# Altium schematic sheet Pag5_Perifericos.SchDoc(Pag5_Perifericos)
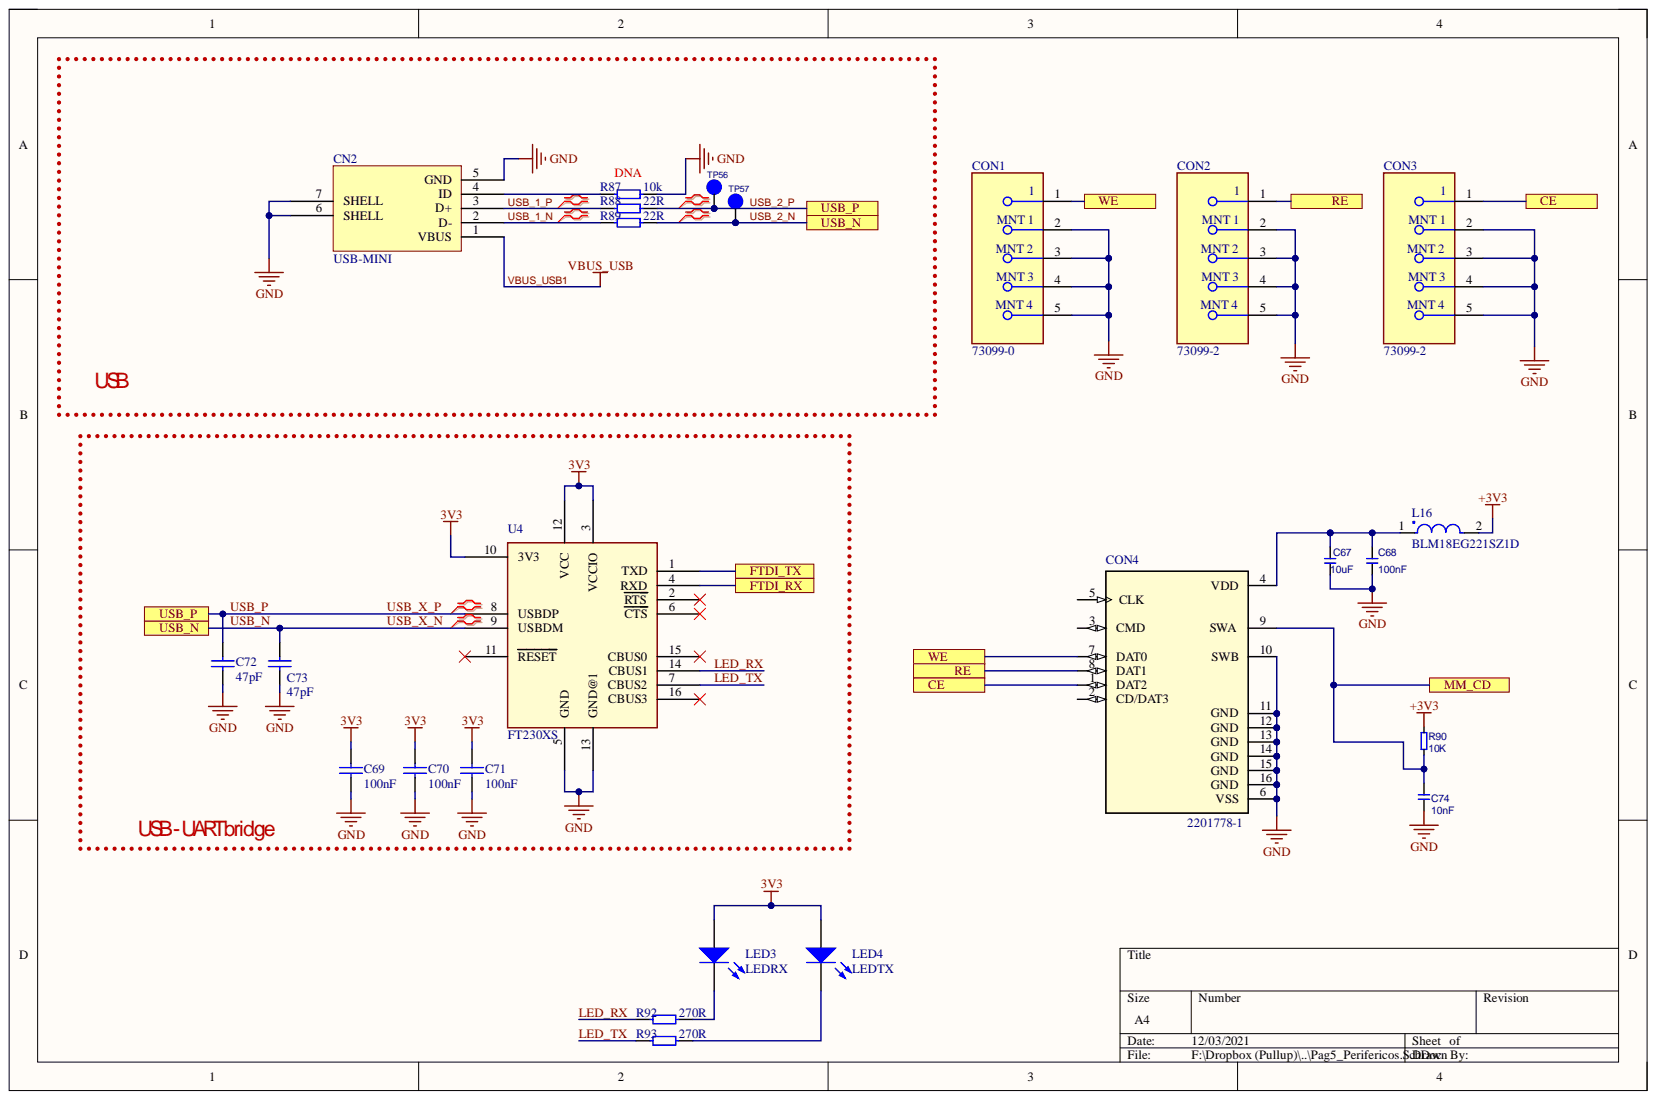
[diagram p0: Pag5_Perifericos.SchDoc]

## Parts
C67  10uF  [0603]
C68  100nF  [0603]
C69  100nF  [0603]
C70  100nF  [0603]
C71  100nF  [0603]
C72  47pF  [0603]
C73  47pF  [0603]
C74  10nF  [0603]
CN2  USB-MINI  [TE_2172034-1]
CON1  73099-0  [FP-73099-0-MFG]
CON2  73099-2  [FP-73099-2-MFG]
CON3  73099-2  [FP-73099-2-MFG]
CON4  2201778-1  [TE_2201778-1]
L16  BLM18EG221SZ1D  [IND_2012]
LED3  LEDRX  [0603 - LED]
LED4  LEDTX  [0603 - LED]
R87  10k  PCBA: DNA  [0603]
R88  22R  [0603]
R89  22R  [0603]
R90  10K  [0603]
R92  270R  [0603]
R93  270R  [0603]
TP56  Test_Point  [TEST]  (value not drawn)
TP57  Test_Point  [TEST]  (value not drawn)
U4  FT230XS  [SSOP-16-2]

## Ports
CE, FTDI_RX, FTDI_TX, MM_CD, RE, USB_N, USB_P, WE

## Nets
3V3: C69.2 C70.2 C71.2 L16.2 LED3.1 LED4.1 R90.2 U4.3(VCCIO) U4.10(3V3) U4.12(VCC)
GND: C67.1 C68.1 C69.1 C70.1 C71.1 C72.1 C73.1 C74.1 CN2.5(GND) CN2.6(SHELL) CN2.7(SHELL) CON1.2 CON1.3 CON1.4 CON1.5 CON2.2 CON2.3 CON2.4 CON2.5 CON3.2 CON3.3 CON3.4 CON3.5 CON4.6(VSS) CON4.10(SWB) CON4.11(GND) CON4.12(GND) CON4.13(GND) CON4.14(GND) CON4.15(GND) CON4.16(GND) R87.1 U4.5(GND) U4.13(GND@1)
CE: CON3.1 CON4.1(DAT2) ; port CE
FTDI_RX: U4.4(RXD) ; port FTDI_RX
FTDI_TX: U4.1(TXD) ; port FTDI_TX
LED_RX: R92.1 U4.14(CBUS1)
LED_TX: R93.1 U4.7(CBUS2)
MM_CD: C74.2 CON4.9(SWA) R90.1 ; port MM_CD
RE: CON2.1 CON4.8(DAT1) ; port RE
USB_1_N: CN2.2(D-) R89.2
USB_1_P: CN2.3(D+) R88.2
USB_2_N: C73.2 R89.1 TP57.1 U4.9(USBDM) ; port USB_N
USB_2_P: C72.2 R88.1 TP56.1 U4.8(USBDP) ; port USB_P
VBUS_USB1: CN2.1(VBUS)
WE: CON1.1 CON4.7(DAT0) ; port WE
NetC67_2: C67.2 C68.2 CON4.4(VDD) L16.1
NetCN2_4: CN2.4(ID) R87.2
NetLED3_2: LED3.2 R92.2
NetLED4_2: LED4.2 R93.2

Not connected: CON4 2(CD/DAT3) 3(CMD) 5(CLK); U4 2(RTS) 6(CTS) 11(RESET) 15(CBUS0) 16(CBUS3)

## Library data of the parts
C67 = C-X5R-10uF-6.3V-20%-0603: Capacitor Ceramic X5R 10uF 6.3V 20% 0603; Manufacturer: Murata; MPN: GRM188R61A106KE69J; PCBA: Assembly; Assembly: Assembly; Component Kind: Standard; Datasheet: https://www.mouser.com/datasheet/2/281/murata_03052018_GRM_Series_1-1310166.pdf; Supplier 1: Mouser; Supplier 1 Link: https://www.mouser.com/ProductDetail/Murata-Electronics/GRM188R61A106KE69J?qs=sGAEpiMZZMs0AnBnWHyRQAsAWwhBCY7bp3pFrpMtukFBX6VOqeHlKw%3D%3D; Supplier 1 Part Number: 81-GRM188R61A106KE9J; Value: 10uF; Library Name: Evaluation Board.SchLib
C68 = C-X7R-100nF-16V-10%-0603: Capacitor Ceramic X7R 100nF 16V 10% 0603; Manufacturer: KEMET; MPN: C0603C104M5RACTU; PCBA: Assembly; Assembly: Assembly; Component Kind: Standard; Datasheet: https://www.mouser.com/datasheet/2/212/KEM_C1002_X7R_SMD-1102033.pdf; Supplier 1: Mouser; Supplier 1 Link: https://www.mouser.com/ProductDetail/KEMET/C0603C104M5RACTU?qs=sGAEpiMZZMs0AnBnWHyRQG8EQLxJcrqdghOfJCcQoTg%3D; Supplier 1 Part Number: 80-C0603C104M5R; Value: 100nF; Library Name: Evaluation Board.SchLib
C69-C71 = Cap: Alternate_PartNumber: 77-VJ0603Y104KXAAC; Manufacturer: KEMET; MPN: C0603C104M5RACTU; Additional value: 50V; PCBA: Assembly; Component Kind: Standard; Link Supplier: https://www.mouser.com/ProductDetail/KEMET/C0603C104M5RACTU?qs=sGAEpiMZZMs0AnBnWHyRQG8EQLxJcrqdghOfJCcQoTg%3d; Supplier 1: Mouser; Supplier Part Number 1: 80-C0603C104M5R; Value: 100nF; Library Name: LIBSCH_PULLUP.SchLib
C72 C73 = Cap: Manufacturer: Vishay; MPN: VJ0603A470JXXPW1BC; Additional value: 25V; PCBA: Assembly; Component Kind: Standard; Link Supplier: https://www.mouser.com/ProductDetail/Vishay-Vitramon/VJ0603A470JXXPW1BC?qs=sGAEpiMZZMs0AnBnWHyRQGchlqIXPxeoiWP5wr2B95A%3d; Supplier 1: Mouser; Supplier Part Number 1: 77-VJ0603A470JXXPBC; Value: 47pF; Library Name: LIBSCH_PULLUP.SchLib
C74 = C-X7R-10nF-25V-10%-0603: Capacitor Ceramic X7R 10nF 25V 10% 0603; Manufacturer: Wurth Electronics; MPN: 885012206089; PCBA: Assembly; Assembly: Assembly; Component Kind: Standard; Datasheet: https://www.mouser.com/datasheet/2/445/885012206089-554597.pdf; Supplier 1: Mouser; Supplier 1 Link: https://www.mouser.com/ProductDetail/Wurth-Electronics/885012206089?qs=sGAEpiMZZMs0AnBnWHyRQEGbLOF2VP1i3S0Na0CoPiiM3E4YQho5UQ%3D%3D; Supplier 1 Part Number: 710-885012206089; Value: 10nF; Library Name: Evaluation Board.SchLib
CN2 = USB-MINI: Manufacturer: TE Connectivity AMP Connectors; MPN: 2172034-1; PCBA: Assembly; Component Kind: Standard; Datasheet: https://www.te.com/commerce/DocumentDelivery/DDEController?Action=srchrtrv&DocNm=2172034&DocType=Customer+Drawing&DocLang=English; Supplier 1: Mouser; Supplier 1 Link: https://www.digikey.com/products/en?keywords=2172034-1; Supplier 1 Part Number: A135698-ND; Library Name: LIBSCH_PULLUP.SchLib
CON1 = CMP-73906-000007-1: R/A 4MM MOUNTG SAFE JACK/PCB BLK; Component Kind: Standard; Library Name: Altium Content Vault
CON2 CON3 = CMP-73906-000005-1: R/A 4MM MOUNTG SAFE JACK/PCB RED; Component Kind: Standard; Library Name: Altium Content Vault
CON4 = 2201778-1: Manufacturer: TE Connectivity; PCBA: Assembly; Assembly: Assembly; Component Kind: Standard; Datasheet: https://br.mouser.com/datasheet/2/418/PN_CD_2201778_B-1150756.pdf; MPN: 2201778-1; Supplier 1: Mouser; Supplier 1 Link: https://br.mouser.com/ProductDetail/TE-Connectivity/2201778-1?qs=%2Fha2pyFaduhKQqqCzRbAPHLtVkN%252BUBVddx%252BllA8YJmfP3LhX2YWQcQ%3D%3D; Supplier 1 Part Number: 571-2201778-1; Value: 2201778-1; Library Name: LIBSCH_PULLUP.SchLib
L16 = IND: Manufacturer: Murata Electronics; PCBA: Assembly; Assembly: Assembly; Component Kind: Standard; Datasheet: https://www.mouser.com/datasheet/2/281/c51e-794816.pdf; MPN: BLM18EG221SZ1D; Supplier 1: Mouser; Supplier 1 Link: https://www.mouser.com/ProductDetail/Murata-Electronics/BLM18EG221SZ1D?qs=sGAEpiMZZMufdu5QM0tCwRc0XOir3CTTXrAwztpVqqU%3D; Supplier 1 Part Number: 81-BLM18EG221SZ1D; Value: BLM18EG221SZ1D; Library Name: LIBSCH_PULLUP.SchLib
LED3 LED4 = LED: Manufacturer: ROHM Semiconductor; MPN: SML-D13M8WT86; Additional value: 572nm; PCBA: Assembly; Component Kind: Standard; Link Supplier: https://www.mouser.com/ProductDetail/ROHM-Semiconductor/SML-D13M8WT86?qs=sGAEpiMZZMvyj6n1w4pZD%2f8C494AiZOqF0HdovjI3W4%3d; Supplier 1: Mouser; Supplier Part Number 1: 755-SML-D13M8WT86; Library Name: LIBSCH_PULLUP.SchLib
R87 = Res: Manufacturer: Yageo; MPN: RC0603JR-0710KL; Component Kind: Standard; Datasheet: https://www.mouser.com/datasheet/2/447/PYu-RC_Group_51_RoHS_L_10-1527934.pdf; Supplier 1: Mouser; Supplier 1 Link: https://www.mouser.com/ProductDetail/Yageo/RC0603JR-0710KL?qs=sGAEpiMZZMu61qfTUdNhG0IfGdGRhTL5km%252bfjRkhZ3w%3d; Supplier 1 Part Number: 603-RC0603JR-0710KL; Value: 10k; Library Name: LIBSCH_PULLUP.SchLib
R88 R89 = Res: Manufacturer: Yageo; MPN: RT0603FRE0722RL; PCBA: Assembly; Component Kind: Standard; Datasheet: https://www.mouser.com/datasheet/2/447/PYu-RT_1-to-0.01_RoHS_L_9-1222720.pdf; Supplier 1: Mouser; Supplier 1 Link: https://www.mouser.com/ProductDetail/Yageo/RT0603FRE0722RL?qs=sGAEpiMZZMvdGkrng054t%252BKCHBXLTLydHS5lkk1PoYI%3D; Supplier 1 Part Number: 603-RT0603FRE0722RL; Value: 22R; Library Name: LIBSCH_PULLUP.SchLib
R90 = R-10KR-63mW-5%-0603: Resistor 10 Kohm 63mW 5% 0603; Manufacturer: Vishay; MPN: CRCW060310K0FKEAC; PCBA: Assembly; Assembly: Assembly; Component Kind: Standard; Datasheet: https://www.mouser.com/datasheet/2/427/crcwce3-1223726.pdf; Supplier 1: Mouser; Supplier 1 Link: https://www.mouser.com/ProductDetail/Vishay/CRCW060310K0FKEAC?qs=sGAEpiMZZMu61qfTUdNhG9bvwnXh9sSrH5TrRKoCf71SgwG4%252BM0wHg%3D%3D; Supplier 1 Part Number: 71-CRCW060310K0FKEAC; Value: 10k; Library Name: Evaluation Board.SchLib
R92 R93 = Res: Alternate_PartNumber: RT0603DRD07270RL; Manufacturer: Yageo; MPN: RC0603FR-07270RL; Additional value: 1/10 W; PCBA: Assembly; Component Kind: Standard; Link Supplier: https://www.mouser.com/ProductDetail/Yageo/RC0603FR-07270RL?qs=sGAEpiMZZMu61qfTUdNhG5eFuApKbqVdSxKkb21PCo4%3d; Supplier 1: Mouser; Supplier Part Number 1: 603-RC0603FR-07270RL; Value: 270R; Library Name: LIBSCH_PULLUP.SchLib
TP56 TP57 = CDO08200-9892: Database Table Name: Outros$; PCBA: DNA; Component Kind: Standard; MPN: Test_Point; Value: Test_Point; Library Name: Database_Pullup.DbLib
U4 = FT230XS: I/F, USB2.0 FS TO BASIC UART, 16SSOP; USB Type: Transceiver; USB Version: 2.0; Data Rate: 3Mbaud; Supply Voltage Rang...; Manufacturer: FTDI; PCBA: Assembly; Component Kind: Standard; Link Supplier: https://br.mouser.com/ProductDetail/FTDI/FT230XS-R?qs=sGAEpiMZZMtv%252bwxsgy%2fhiIaF6qCroMVR1i2pEQA5UpU%3d; MPN: FT230XS; Supplier 1: Mouser; Supplier Part Number 1: 895-FT230XS-R; Value: FT230XS; Library Name: LIBSCH_PULLUP.SchLib
